# Altium schematic sheet sheet3_data.SchDoc
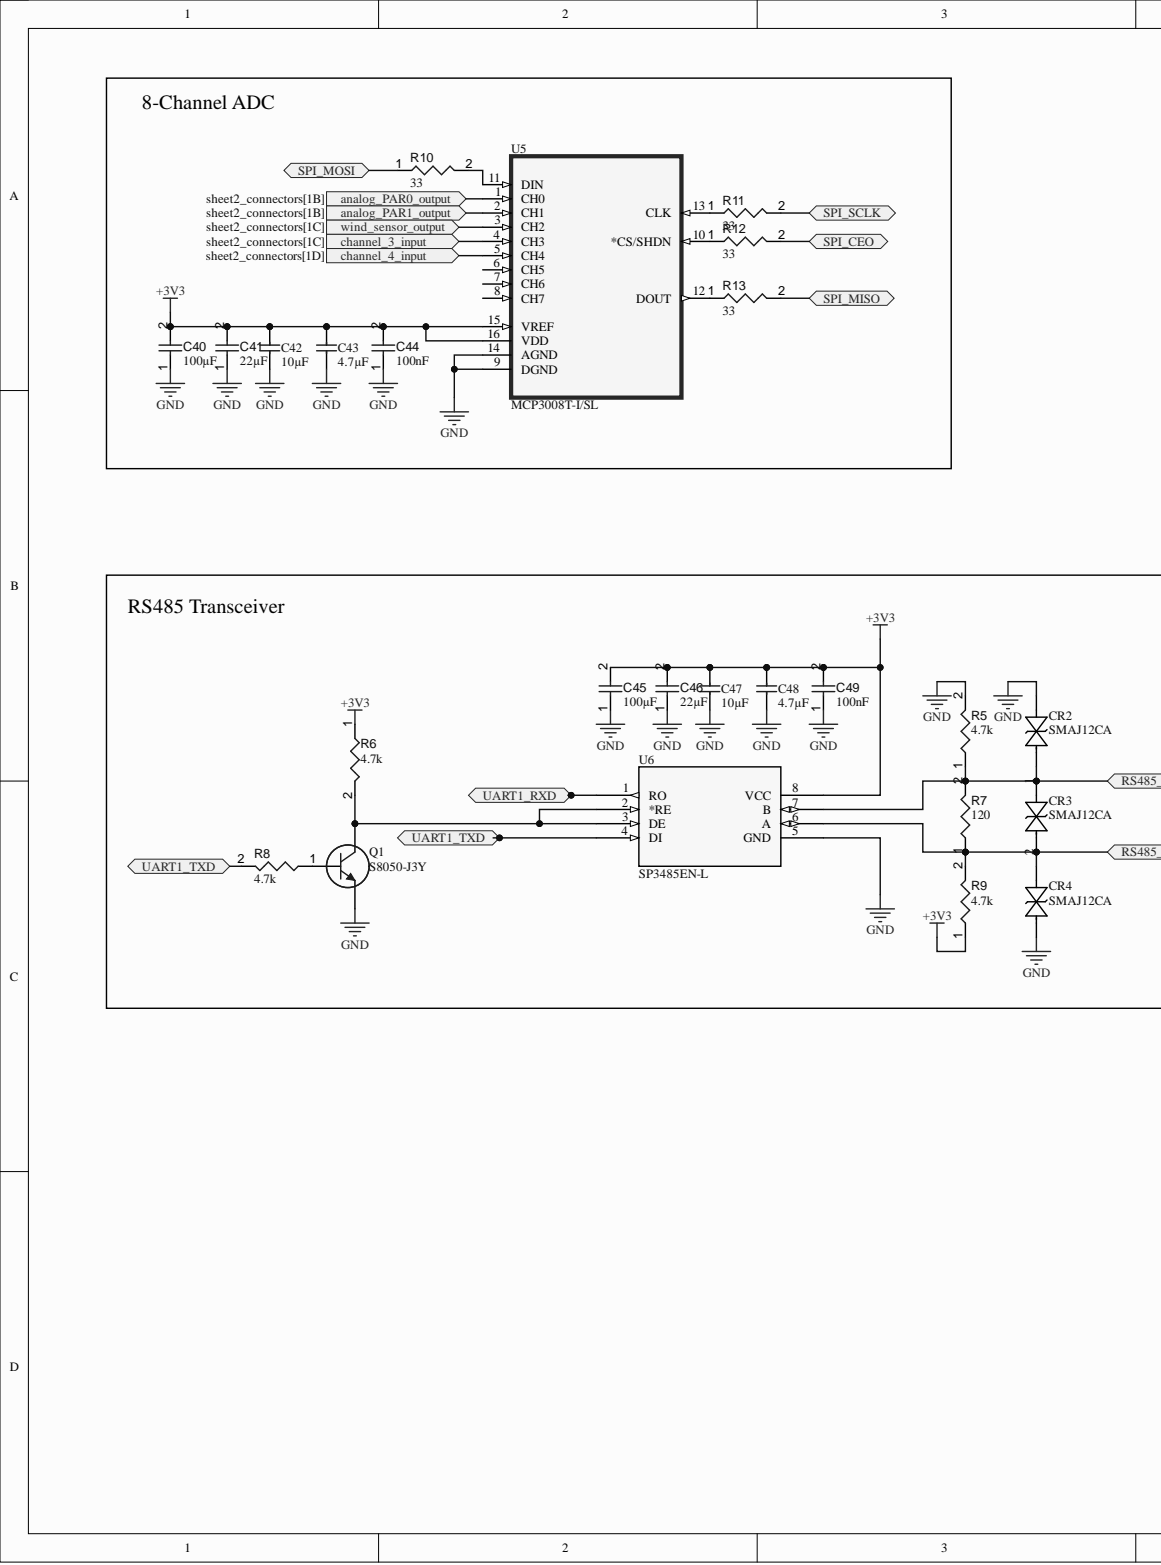
[diagram p0: sheet3_data.SchDoc, left]
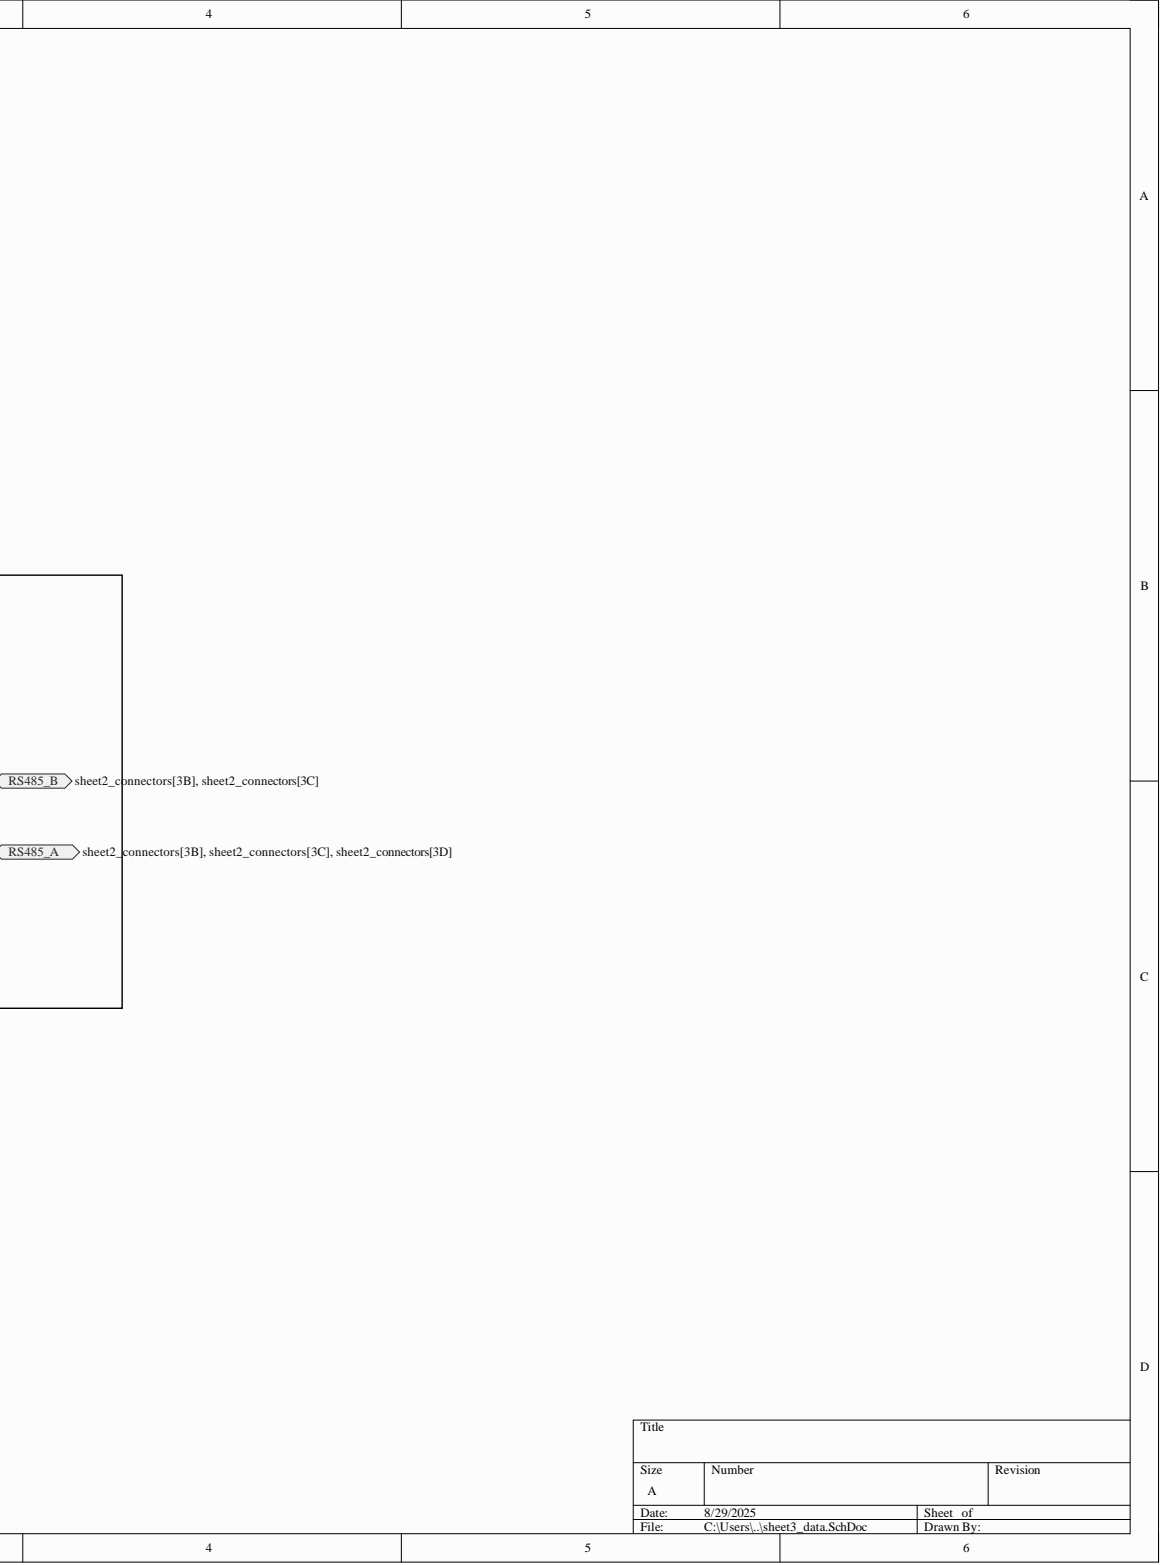
[diagram p1: sheet3_data.SchDoc, right]

## Parts
C40  value 100µF  [CAPC0805(2012)145_L]  (hidden comment: Capacitor 100µF +/-20% 6.3V 0805)
C41  value 22µF  [CAPC0805(2012)145_L]  (hidden comment: Capacitor 22µF +/-40% 16V 0805)
C42  10µF  [CAPC1608X06L]
C43  4.7µF  [CAPC1608X06L]
C44  value 100nF  [CAPC2013X95X50LL10T25]  (hidden comment: Capacitor 100 nF +/-10% 50 V 0805)
C45  value 100µF  [CAPC0805(2012)145_L]  (hidden comment: Capacitor 100µF +/-20% 6.3V 0805)
C46  value 22µF  [CAPC0805(2012)145_L]  (hidden comment: Capacitor 22µF +/-40% 16V 0805)
C47  10µF  [CAPC1608X06L]
C48  4.7µF  [CAPC1608X06L]
C49  value 100nF  [CAPC2013X95X50LL10T25]  (hidden comment: Capacitor 100 nF +/-10% 50 V 0805)
CR2  SMAJ12CA  [SMASeries_LTF]
CR3  SMAJ12CA  [SMASeries_LTF]
CR4  SMAJ12CA  [SMASeries_LTF]
Q1  S8050-J3Y  [S8050-J3Y-Footprint-1]
R5  value 4.7k  [RESC1005X40X25ML05T05]  (hidden comment: Resistor 4k7 +/-1% 0402 100 mW)
R6  value 4.7k  [RESC1005X40X25ML05T05]  (hidden comment: Resistor 4k7 +/-1% 0402 100 mW)
R7  value 120  [RESC0603(1608)_L]  (hidden comment: Resistor 120R +/-5 % 0603 0.1 W)
R8  value 4.7k  [RESC1005X40X25ML05T05]  (hidden comment: Resistor 4k7 +/-1% 0402 100 mW)
R9  value 4.7k  [RESC1005X40X25ML05T05]  (hidden comment: Resistor 4k7 +/-1% 0402 100 mW)
R10  value 33  [RESC0402(1005)_L]  (hidden comment: Resistor 33R  +/-5 % 0402 63 mW)
R11  Resistor 33R  +/-5 % 0402 63 mW  [RESC0402(1005)_L]  (value not drawn)
R12  value 33  [RESC0402(1005)_L]  (hidden comment: Resistor 33R  +/-5 % 0402 63 mW)
R13  value 33  [RESC0402(1005)_L]  (hidden comment: Resistor 33R  +/-5 % 0402 63 mW)
U5  MCP3008T-I/SL  [SOIC127P600X175-16N]
U6  SP3485EN-L  [NSOIC8_MXL]

## Ports
analog_PAR0_output, analog_PAR1_output, channel_3_input, channel_4_input, RS485_A, RS485_B, SPI_CEO, SPI_MISO, SPI_MOSI, SPI_SCLK, UART1_RXD, UART1_TXD, wind_sensor_output

## Nets
+3V3: C40.2 C41.2 C42.2 C43.2 C44.2 C45.2 C46.2 C47.2 C48.2 C49.2 R6.1 R9.1 U5.15(VREF) U5.16(VDD) U6.8(VCC)
GND: C40.1 C41.1 C42.1 C43.1 C44.1 C45.1 C46.1 C47.1 C48.1 C49.1 CR2.1 CR4.2 Q1.1 R5.2 U5.9(DGND) U5.14(AGND) U6.5(GND)
analog_PAR0_output: U5.1(CH0) ; port analog_PAR0_output
analog_PAR1_output: U5.2(CH1) ; port analog_PAR1_output
channel_3_input: U5.4(CH3) ; port channel_3_input
channel_4_input: U5.5(CH4) ; port channel_4_input
RS485_A: CR3.2 CR4.1 R7.1 R9.2 U6.6(A) ; port RS485_A
RS485_B: CR2.2 CR3.1 R5.1 R7.2 U6.7(B) ; port RS485_B
SPI_CEO: R12.2 ; port SPI_CEO
SPI_MISO: R13.2 ; port SPI_MISO
SPI_MOSI: R10.1 ; port SPI_MOSI
SPI_SCLK: R11.2 ; port SPI_SCLK
UART1_RXD: U6.1(RO) ; port UART1_RXD
UART1_TXD: R8.2 U6.4(DI) ; port UART1_TXD
wind_sensor_output: U5.3(CH2) ; port wind_sensor_output
NetQ1_2: Q1.2 R8.1
NetQ1_3: Q1.3 R6.2 U6.2(*RE) U6.3(DE)
NetR10_2: R10.2 U5.11(DIN)
NetR11_1: R11.1 U5.13(CLK)
NetR12_1: R12.1 U5.10(*CS/SHDN)
NetR13_1: R13.1 U5.12(DOUT)

Not connected: U5 6(CH5) 7(CH6) 8(CH7)

## Library data of the parts
C40 C45 = CMP-001-00054-4: Chip Capacitor, 100µF +/-20%, 6.3V, 0805, Thickness 1.45 mm; Tolerance: 10%; Case/Package: 0805; Component Kind: Standard; Max Operating Temperature: 85°C; Min Operating Temperature: -55°C; Mounting Technology: SMT; Pins: 2; Voltage Rating: 6.3V; Library Name: Greenhouse Lysimeter Project
C41 C46 = CMP-001-00081-4: Chip Capacitor, 22µF +/-40%, 16V, 0805, Thickness 1.45 mm; Tolerance: 5%; Case/Package: 0805; Component Kind: Standard; Max Operating Temperature: 85°C; Min Operating Temperature: -55°C; Mounting Technology: SMT; Pins: 2; Voltage Rating: 16V; Library Name: Greenhouse Lysimeter Project
C42 C47 = C0603-10µ: Capacitor, X5R, ±20%; Manufacturer: N.A.; Part number IPS: 00000007785; Artikkelnr Noca: 20039234; Note: 6.3V; Pad Count: 2; Component Class: Capacitors; Component Kind: Standard; ComponentLink1Description: Datasheet; ComponentLink1URL: N.A.; ComponentLink2Description: Supplier link; ComponentLink2URL: N.A.; Footprint Doc: 0603; HelpURL: N.A.; LatestRevisionDate: 2011-01-17; Library Name: pca10040.IntLib; Manufacturer Part Number: N.A.; Part Number: C0603-10µ; Part number Norautron: N.A.; PartId: 100200275; Published: 2011-01-17; Supplier 1: N.A.; Supplier 2: N.A.; Supplier Part Number 1: N.A.; Supplier Part Number 2: N.A.; Value: 10µF; Author: rsk
C43 C48 = C0603-4µ7-10V-X7S: Capacitor, X7S, ±10%, 10V; Manufacturer: N.A.; Part number IPS: 00000001779; Artikkelnr Noca: 20030013; Pad Count: 2; Component Class: Capacitors; Component Kind: Standard; ComponentLink1Description: Datasheet; ComponentLink1URL: N.A.; ComponentLink2Description: Supplier link; ComponentLink2URL: N.A.; Footprint Doc: 0603; HelpURL: N.A.; LatestRevisionDate: 2016-08-15; Library Name: pca10040.IntLib; Manufacturer Part Number: N.A.; Note: 10V; Part Number: C0603-4µ7-10V-X7S; Part number Norautron: N.A.; PartId: 100200351; Published: 2016-08-15; Supplier 1: Digi-Key; Supplier 2: N.A.; Supplier Part Number 1: 445-14258-1-ND; Supplier Part Number 2: N.A.; Value: 4.7µF; Author: rsk
C44 C49 = CMP-001-00018-6: Chip Capacitor, 100 nF, +/- 10%, 50 V, 0805 (2012 Metric); Capacitor Type: Ceramic; Voltage Rating: 50V; Case/Package: 0805; Component Kind: Standard; Max Operating Temperature: 125°C; Min Operating Temperature: -55°C; Mounting Technology: SM; Pins: 2; RoHS Compliant: True; Tolerance: 10%; Library Name: Greenhouse Lysimeter Project
CR2-CR4 = CMP-017-000000-1: No Description Available; Mfr_Name: Littelfuse Inc; Component Kind: Standard; Design Item ID: SMAJ12CA; Source: SMAJ12CA.SchLib; Library Name: Greenhouse Lysimeter Project
Q1 = CMP-013-00038-1: NPN SOT-23 Bipolar (BJT) ROHS; Component Kind: Standard; Library Name: Greenhouse Lysimeter Project
R5 R6 R8 R9 = CMP-009-00030-5: Chip Resistor, 4.7 KOhm, +/- 1%, 0.1 W, -55 to 155 degC, 0402 (1005 Metric); Power: 100mW; Voltage Rating: 50V; Case/Package: 0402; Component Kind: Standard; Max Operating Temperature: 155°C; Min Operating Temperature: -55°C; Mounting Technology: SM; Pins: 2; RoHS Compliant: True; Tolerance: 1%; Library Name: Greenhouse Lysimeter Project
R7 = CMP-009-00176-3: Chip Resistor, 120 Ohms, +/-5 %, 0.1 W, -55 to 155 degC, 0603; Power: 100mW; Tolerance: 5%; Case/Package: 0603; Component Kind: Standard; Max Operating Temperature: 155°C; Min Operating Temperature: -55°C; Pins: 2; Library Name: Greenhouse Lysimeter Project
R10 R12 R13 = CMP-009-00143-4: Chip Resistor, 33 Ohms, +/-5 %, 63 mW, -55 to 155 degC, 0402; Power: 63mW; Tolerance: 5%; Case/Package: 0402; Component Kind: Standard; Max Operating Temperature: 155°C; Min Operating Temperature: -55°C; Pins: 2; Library Name: Greenhouse Lysimeter Project
R11 = CMP-009-00143-4: Chip Resistor, 33 Ohms, +/-5 %, 63 mW, -55 to 155 degC, 0402; Power: 63mW; Tolerance: 5%; Value: 33; Case/Package: 0402; Component Kind: Standard; Max Operating Temperature: 155°C; Min Operating Temperature: -55°C; Pins: 2; Library Name: Greenhouse Lysimeter Project
U5 = CMP-021-000000-1: 10-bit ADC, SPI, 8-channel16 SOIC .150in T/R; MF: Microchip Technology; Check_prices: https://www.snapeda.com/parts/MCP3008T-I/SL/Microchip/view-part/?ref=eda; Component Kind: Standard; Component Type: Standard; Design Item ID: MCP3008T-I/SL; MP: MCP3008T-I/SL; Package: SOIC-16 Microchip; Pin Count: 16; SnapEDA_Link: https://www.snapeda.com/parts/MCP3008T-I/SL/Microchip/view-part/?ref=snap; Source: MCP3008T-I_SL.SchLib; Library Name: Greenhouse Lysimeter Project
U6 = CMP-014-000000-1: No Description Available; Mfr_Name: MaxLinear / Exar; Component Kind: Standard; Design Item ID: SP3485EN-L; RefDes: RefDes; Source: SP3485EN-L.SchLib; Type: Type; Library Name: Greenhouse Lysimeter Project
